# Altium schematic sheet PMTControl.SchDoc
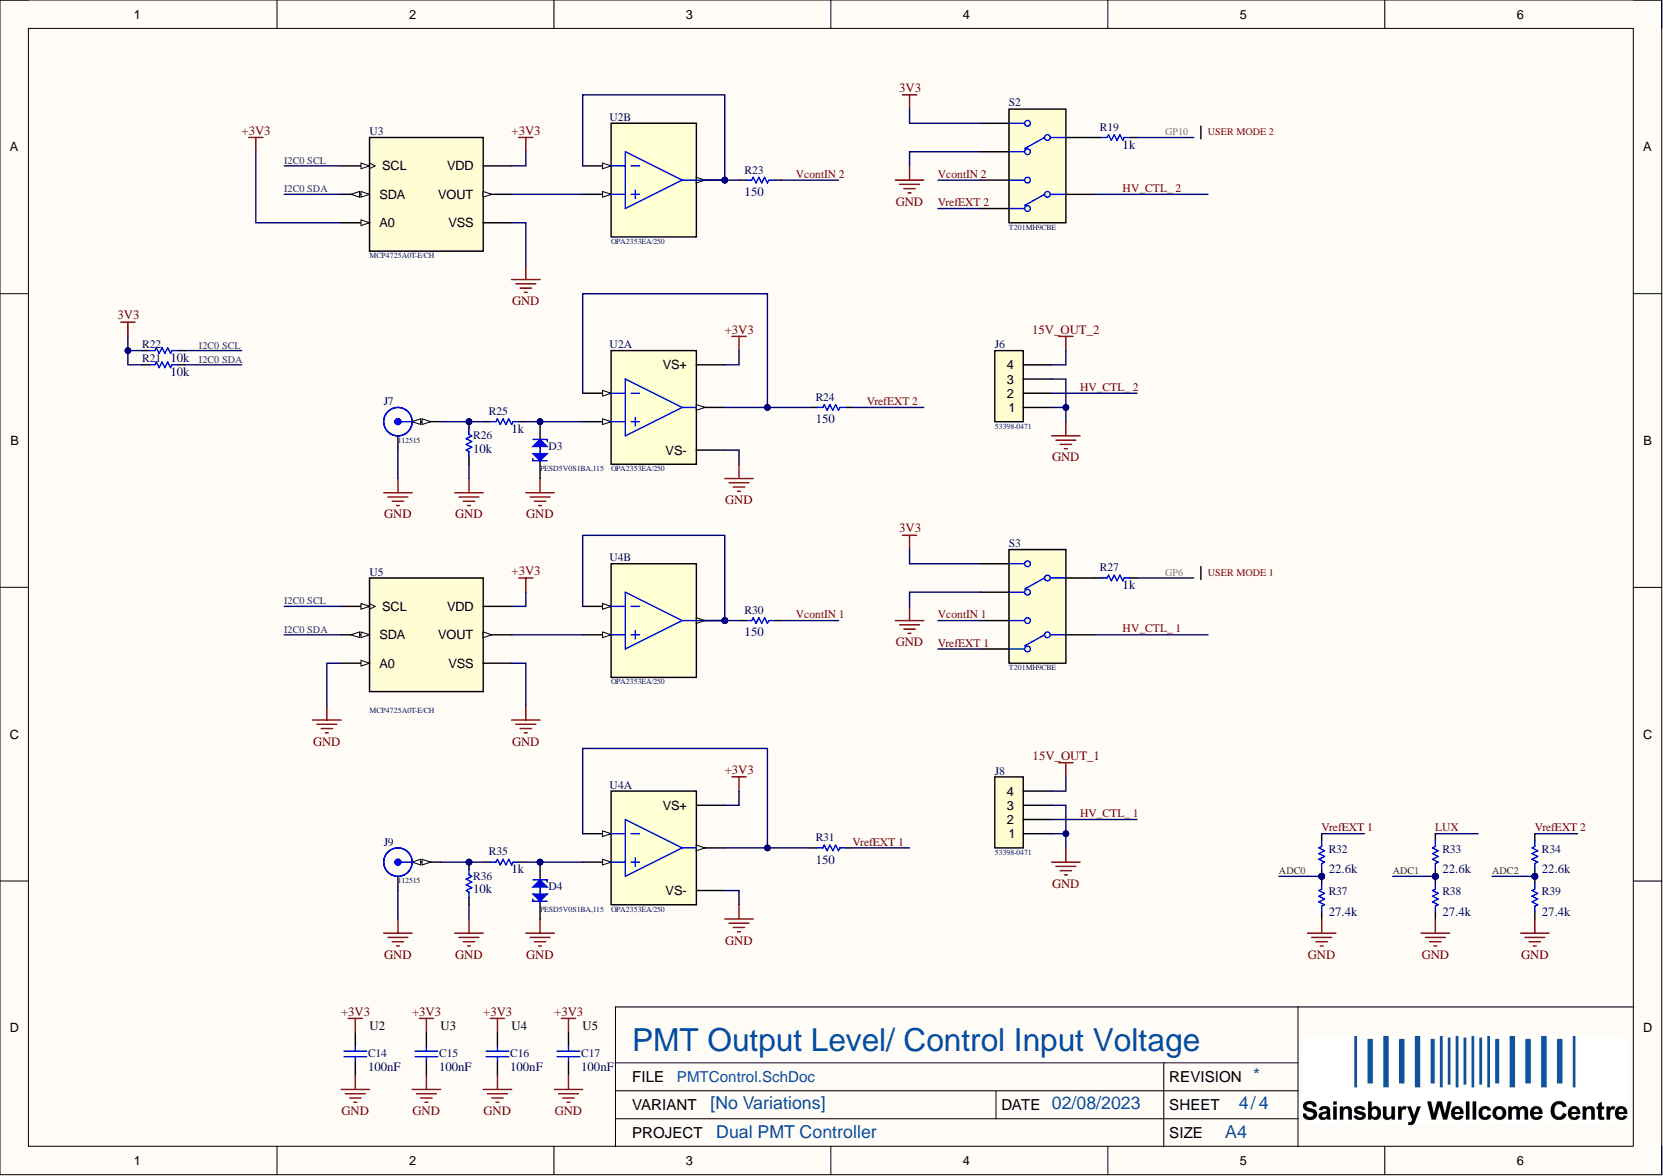
[diagram p0: PMTControl.SchDoc]

## Parts
C14  value 100nF  Capacitance: 100nF  [FP-GRM188-0_1-e0_2_0_5-IPC_B]  (hidden comment: C0603-100nF-100V-10%-X7R)
C15  value 100nF  Capacitance: 100nF  [FP-GRM188-0_1-e0_2_0_5-IPC_B]  (hidden comment: C0603-100nF-100V-10%-X7R)
C16  value 100nF  Capacitance: 100nF  [FP-GRM188-0_1-e0_2_0_5-IPC_B]  (hidden comment: C0603-100nF-100V-10%-X7R)
C17  value 100nF  Capacitance: 100nF  [FP-GRM188-0_1-e0_2_0_5-IPC_B]  (hidden comment: C0603-100nF-100V-10%-X7R)
D3  PESD5V0S1BA,115  [FP-SOD323-CA-IPC_B]
D4  PESD5V0S1BA,115  [FP-SOD323-CA-IPC_B]
J6  53398-0471  [FP-533980471-MFG]
J7  112515  [AMP_112515]
J8  53398-0471  [FP-533980471-MFG]
J9  112515  [AMP_112515]
R19  value 1k  Resistance: 1k  [FP-CPF0603-IPC_B]  (hidden comment: R0603-1k-1/16W-0.1%)
R21  value 10k  Resistance: 10k  [FP-CPF0603-IPC_B]  (hidden comment: R0603-10k-1/16W-0.1%)
R22  value 10k  Resistance: 10k  [FP-CPF0603-IPC_B]  (hidden comment: R0603-10k-1/16W-0.1%)
R23  value 150  [FP-CPF0603-IPC_B]  (hidden comment: R0603-150R-1/16W-0.1%)
R24  value 150  [FP-CPF0603-IPC_B]  (hidden comment: R0603-150R-1/16W-0.1%)
R25  value 1k  Resistance: 1k  [FP-CPF0603-IPC_B]  (hidden comment: R0603-1k-1/16W-0.1%)
R26  value 10k  Resistance: 10k  [FP-CPF0603-IPC_B]  (hidden comment: R0603-10k-1/16W-0.1%)
R27  value 1k  Resistance: 1k  [FP-CPF0603-IPC_B]  (hidden comment: R0603-1k-1/16W-0.1%)
R30  value 150  [FP-CPF0603-IPC_B]  (hidden comment: R0603-150R-1/16W-0.1%)
R31  value 150  [FP-CPF0603-IPC_B]  (hidden comment: R0603-150R-1/16W-0.1%)
R32  value 22.6k  Resistance: 22.6k  [FP-CPF0603-IPC_B]  (hidden comment: R0603-22k6-1/16W-0.1%)
R33  value 22.6k  Resistance: 22.6k  [FP-CPF0603-IPC_B]  (hidden comment: R0603-22k6-1/16W-0.1%)
R34  value 22.6k  Resistance: 22.6k  [FP-CPF0603-IPC_B]  (hidden comment: R0603-22k6-1/16W-0.1%)
R35  value 1k  Resistance: 1k  [FP-CPF0603-IPC_B]  (hidden comment: R0603-1k-1/16W-0.1%)
R36  value 10k  Resistance: 10k  [FP-CPF0603-IPC_B]  (hidden comment: R0603-10k-1/16W-0.1%)
R37  value 27.4k  Resistance: 27.4k  [FP-CPF0603-IPC_B]  (hidden comment: R0603-27k4-1/16W-0.1%)
R38  value 27.4k  Resistance: 27.4k  [FP-CPF0603-IPC_B]  (hidden comment: R0603-27k4-1/16W-0.1%)
R39  value 27.4k  Resistance: 27.4k  [FP-CPF0603-IPC_B]  (hidden comment: R0603-27k4-1/16W-0.1%)
S2  T201MH9CBE  [SWITCH_T201MH9CBE]
S3  T201MH9CBE  [SWITCH_T201MH9CBE]
U2  OPA2353EA/250  [DGK0008A_N]  (parts A,B)
U3  MCP4725A0T-E/CH  [FP-C04-028-CHY-MFG]
U4  OPA2353EA/250  [DGK0008A_N]  (parts A,B)
U5  MCP4725A0T-E/CH  [FP-C04-028-CHY-MFG]

## Nets
3V3: C14.2 C15.2 C16.2 C17.2 R21.2 R22.2 S2.1 S3.1 U2.8(VS+) U3.3(VDD) U3.6(A0) U4.8(VS+) U5.3(VDD)
15V_OUT_1: J8.4
15V_OUT_2: J6.4
GND: C14.1 C15.1 C16.1 C17.1 D3.1 D4.1 J6.1 J6.3 J7.2 J8.1 J8.3 J9.2 R26.1 R36.1 R37.1 R38.1 R39.1 S2.3 S3.3 U2.4(VS-) U3.2(VSS) U4.4(VS-) U5.2(VSS) U5.6(A0)
ADC0: R32.1 R37.2
ADC1: R33.1 R38.2
ADC2: R34.1 R39.2
GP6: R27.2
GP10: R19.2
HV_CTL_ 1: J8.2 S3.5
HV_CTL_ 2: J6.2 S2.5
I2C0 SCL: R22.1 U3.5(SCL) U5.5(SCL)
I2C0 SDA: R21.1 U3.4(SDA) U5.4(SDA)
LUX: R33.2
VcontIN 1: R30.2 S3.4
VcontIN 2: R23.2 S2.4
VrefEXT 1: R31.2 R32.2 S3.6
VrefEXT 2: R24.2 R34.2 S2.6
NetD3_2: D3.2 R25.2 U2.3
NetD4_2: D4.2 R35.2 U4.3
NetJ7_1: J7.1 R25.1 R26.2
NetJ9_1: J9.1 R35.1 R36.2
NetR19_1: R19.1 S2.2
NetR23_1: R23.1 U2.6 U2.7
NetR24_1: R24.1 U2.1 U2.2
NetR27_1: R27.1 S3.2
NetR30_1: R30.1 U4.6 U4.7
NetR31_1: R31.1 U4.1 U4.2
NetU2_5: U2.5 U3.1(VOUT)
NetU4_5: U4.5 U5.1(VOUT)

## Library data of the parts
C14-C17 = CMP-611-0027-19: 0.1µF ±10% 100V Ceramic Capacitor X7R 0603 (1608 Metric); Pick&PlaceFootprint: 0603_CAP; Capacitor Type: Ceramic; Case Code (Imperial): 0603; Case Code (Metric): 1608; Case/Package: 0603; Component Kind: Standard; Construction: Flat; Depth: 0.8mm; Dielectric: X7R; Height: 0.8mm; Lead Free: Lead Free; Length: 1.6mm; Material: Ceramic; Max Operating Temperature: 125°C; Min Operating Temperature: -55°C; Mount: Surface Mount; Package Quantity: 1; Packaging: Tape and Reel; Radiation Hardening: No; REACH SVHC: No SVHC; RoHS: Yes; RoHS Compliant: Yes; Series: GRM; Size: 0.8mm; Termination: SMD/SMT; Thickness: 0.035inch; Tolerance: 10%; Voltage: 100V; Voltage Rating: 100V; Voltage Rating (DC): 100V; Weight: 0.000222oz; Width: 0.8mm; Library Name: Sainsbury Wellcome Centre
D3 D4 = CMP-820-0001-1: Trans Voltage Suppressor Diode, 130W, 5V V(RWM), Bidirectional, 1 Element, Silicon; Max Breakdown Voltage: 9.5V; Min Breakdown Voltage: 5.5V; Number of Elements: 1; Package Body Material: Plastic; Pick&PlaceFootprint: SOD323; Reference Standard: IEC-60134; Time @ Peak Reflow Temperature-Max (s): 30s; Component Kind: Standard; Diode Element Material: SILICON; Diode Type: TRANS VOLTAGE SUPPRESSOR; JESD-30 Code: R-PDSO-G2; JESD-609 Code: e3; Max Operating Temperature: 150°C; Moisture Sensitivity Level: 1; Mount: Surface Mount; Non-rep Peak Rev Power Dis-Max: 130W; Number of Pins: 2; Package Shape: Rectangular; Package Style: SMALL OUTLINEMeter; PartNumber: PESD5V0S1BA,115; Peak Reflow Temperature (Cel): 260°C; Polarity: Bidirectional; REACH SVHC: Yes; Rep Pk Reverse Voltage-Max: 5V; RoHS Compliant: Yes; Surface Mount: Yes; Technology: AVALANCHE; Terminal Finish: Tin; Terminal Form: Gull Wing; Terminal Position: DUAL; Library Name: Sainsbury Wellcome Centre
J6 J8 = CMP-370-0030-2: Board Connector, 4 Contact(s), 1 Row(s), Male, Straight, 0.049 inch Pitch, Surface Mount Terminal, Locking, Natural Insulator, Receptacle; Board Mounting Option: FITTING NAIL; Body Breadth: 0.146inch; Body Depth: 0.185inch; Body Length: 0.383inch; Contact Pattern: RECTANGULAR; Contact Resistance: 20mR; Contact Style: Blade Pin; Dielectric Withstanding Voltage (AC): 250V; DIN Conformance: No; Durability: 30Cycles; Insulation Resistance: 100MR; Mating Information: MULTIPLE MATING PARTS AVAILABLE; Number Of Connectors: 1; Number of Contacts: 4; Option: General Purpose; Plating Thickness: 39uin; Polarization Key: POLARIZED HOUSING; Rated Current (Signal): 1A; Reference Standard: UL; Reliability: COMMERCIAL; Body/Shell Style: RECEPTACLE; Component Kind: Standard; Connector Type: BOARD CONNECTOR; Contact Finish - Mating: MATTE TIN (39) OVER NICKEL; Contact Finish - Termination: Matte Tin (Sn) - with Nickel (Ni) barrier; Contact Gender: Male; Contact Material: Bronze; Filter Feature: No; Flammability Rating: UL94 V-0; IEC Conformance: No; Insulation Color: Natural; Insulation Material: Polyamide; JESD-609 Code: e3; Mating Contact Pitch: 0.049inch; Max Operating Temperature: 85°C; MIL Conformance: No; Min Operating Temperature: -40°C; Mixed Contacts: No; Mount: Board; Mounting Option 1: LOCKING; Mounting Style: STRAIGHT; Number Of PCB Rows: 1; Number of Rows Loaded: 1; PartNumber: 53398-0471; PCB Contact Pattern: RECTANGULAR; REACH SVHC: Yes; RoHS Compliant: Yes; Terminal Pitch: 1.25mm; Termination: SMD/SMT; Library Name: Sainsbury Wellcome Centre
J7 J9 = CMP-730-0003-6: RF BNC Connector, Female, Board Mount, Solder Terminal, Jack; Characteristic Impedance: 50R; Coupling Type: Bayonet; DIN Conformance: No; Option: General Purpose; Panel Mount: No; Body/Shell Style: JACK; Component Kind: Standard; Connector Type: BNC; Contact Finish - Mating: NOT SPECIFIED; Contact Gender: Female; Filter Feature: No; IEC Conformance: No; Insulation Material: POLYTETRAFLUORO; MIL Conformance: No; Mount: Board; Mounting Style: STRAIGHT; PartNumber: 112515; REACH SVHC: No SVHC; RoHS Compliant: Yes; Termination: Solder; Library Name: Sainsbury Wellcome Centre
R19 R25 R27 R35 = CMP-633-0013-15: Surface Mount Resistor 1k Ohm 1/16 W 0.1% 0603 (1608 Metric); Max Power Dissipation: 62.5 mW; Number of Resistors: 1; Pick&PlaceFootprint: R0603; Power Rating: 62.5mW; Temperature Coefficient: 25ppm/°C; Voltage Rating: 50V; Case Code (Imperial): 0603; Case Code (Metric): 1608; Case/Package: 0603; Component Kind: Standard; Composition: Thin Film; ELV: Compliant; Height: 0.45mm; Length: 1.6mm; Max Operating Temperature: 155°C; Min Operating Temperature: -55°C; Mount: Surface Mount; Number of Pins: 2; Number of Terminations: 2; Packaging: Tape and Reel; REACH SVHC: No SVHC; Resistance Tolerance: 0.1%; Resistor Type: Thin Film; RoHS: Yes; RoHS Compliant: Yes; Series: CPF; Size Code: 0603; Technology: Thin Film; Termination: Solder; Tolerance: 0.1%; Voltage Rating (DC): 50V; Weight: 7.1E-05oz; Width: 0.8mm; Library Name: Sainsbury Wellcome Centre
R21 R22 R26 R36 = CMP-633-0002-15: Surface Mount Resistor 10k Ohm 1/16 W 0.1% 0603 (1608 Metric); Max Power Dissipation: 62.5 mW; Number of Resistors: 1; Pick&PlaceFootprint: R0603; Power Rating: 62.5mW; Temperature Coefficient: 25ppm/°C; Voltage Rating: 50V; Case Code (Imperial): 0603; Case Code (Metric): 1608; Case/Package: 0603; Component Kind: Standard; Composition: Thin Film; ELV: Compliant; Height: 0.45mm; Length: 1.6mm; Max Operating Temperature: 155°C; Min Operating Temperature: -55°C; Mount: Surface Mount; Number of Pins: 2; Number of Terminations: 2; Packaging: Tape and Reel; REACH SVHC: No SVHC; Resistance Tolerance: 0.1%; Resistor Type: Thin Film; RoHS: Yes; RoHS Compliant: Yes; Series: CPF; Size Code: 0603; Technology: Thin Film; Termination: Solder; Tolerance: 0.1%; Voltage Rating (DC): 50V; Weight: 7.1E-05oz; Width: 0.8mm; Library Name: Sainsbury Wellcome Centre
R23 R24 R30 R31 = CMP-633-0078-2: Surface Mount Resistor 150 Ohm 1/16 W 0.1% 0603 (1608 Metric); Max Power Dissipation: 62.5 mW; Number of Resistors: 1; Pick&PlaceFootprint: R0603; Power Rating: 62.5mW; Temperature Coefficient: 25ppm/°C; Voltage Rating: 50V; Case Code (Imperial): 0603; Case Code (Metric): 1608; Case/Package: 0603; Component Kind: Standard; Composition: Thin Film; Construction: Chip; ELV: Compliant; Height: 0.45mm; Length: 1.6mm; Max Operating Temperature: 155°C; Min Operating Temperature: -55°C; Mount: Surface Mount; Number of Pins: 2; Number of Terminations: 2; Packaging: Tape and Reel; REACH SVHC: Yes; Resistance: 150; Resistance Tolerance: 0.1%; Resistor Type: FIXED RESISTOR; RoHS: Yes; RoHS Compliant: Yes; Series: CPF; Size Code: 0603; Technology: THIN FILM; Termination: Wraparound; Tolerance: 0.1%; Voltage Rating (DC): 50V; Weight: 7.1E-05oz; Width: 0.8mm; Library Name: Sainsbury Wellcome Centre
R32-R34 = CMP-633-0059-13: Surface Mount Resistor 22.6k Ohm 1/16 W 0.1% 0603 (1608 Metric); Max Power Dissipation: 62.5 mW; Number of Resistors: 1; Pick&PlaceFootprint: R0603; Power Rating: 62.5mW; Temperature Coefficient: 25ppm/°C; Voltage Rating: 50V; Case Code (Imperial): 0603; Case Code (Metric): 1608; Case/Package: 0603; Component Kind: Standard; Composition: Thin Film; ELV: Compliant; Height: 0.45mm; Length: 1.6mm; Max Operating Temperature: 155°C; Min Operating Temperature: -55°C; Mount: Surface Mount; Number of Pins: 2; Number of Terminations: 2; Packaging: Tape and Reel; REACH SVHC: No SVHC; Resistance Tolerance: 0.1%; Resistor Type: Thin Film; RoHS: Yes; RoHS Compliant: Yes; Series: CPF; Size Code: 0603; Technology: Thin Film; Termination: Solder; Tolerance: 0.1%; Voltage Rating (DC): 50V; Weight: 7.1E-05oz; Width: 0.8mm; Library Name: Sainsbury Wellcome Centre
R37-R39 = CMP-633-0060-13: Surface Mount Resistor 27.4k Ohm 1/16 W 0.1% 0603 (1608 Metric); Max Power Dissipation: 62.5 mW; Number of Resistors: 1; Pick&PlaceFootprint: R0603; Power Rating: 62.5mW; Temperature Coefficient: 25ppm/°C; Voltage Rating: 50V; Case Code (Imperial): 0603; Case Code (Metric): 1608; Case/Package: 0603; Component Kind: Standard; Composition: Thin Film; ELV: Compliant; Height: 0.45mm; Length: 1.6mm; Max Operating Temperature: 155°C; Min Operating Temperature: -55°C; Mount: Surface Mount; Number of Pins: 2; Number of Terminations: 2; Packaging: Tape and Reel; REACH SVHC: No SVHC; Resistance Tolerance: 0.1%; Resistor Type: Thin Film; RoHS: Yes; RoHS Compliant: Yes; Series: CPF; Size Code: 0603; Technology: Thin Film; Termination: Solder; Tolerance: 0.1%; Voltage Rating (DC): 50V; Weight: 7.1E-05oz; Width: 0.8mm; Library Name: Sainsbury Wellcome Centre
S2 S3 = CMP-B00-0010-1: Toggle Switch, DPDT, On-on, Horizontal, 6 PCB Hole Cnt, Solder Terminal, Toggle Bat Actuator, Through Hole-straight; Case/Package: MH9CBE; Component Kind: Standard; Mount: Through Hole; PartNumber: T201MH9CBE; Library Name: Sainsbury Wellcome Centre
U2 U4 = CMP-941-0006-5: Operational Amplifier, 2 Func, 8000uV Offset-Max, CMOS, PDSO8; Package Equivalence Code: TSSOP8,.19; Pick&PlaceFootprint: TSSOP8; Component Kind: Standard; PartNumber: OPA2353EA/250; Library Name: Sainsbury Wellcome Centre
U3 U5 = CMP-9B0-0000-1: DAC 1-CH Resistor-String 12-bit 6-Pin SOT-23A T/R; Input Offset Voltage (Vos): 1.1mV; Input Type: SERIAL; Integral Nonlinearity (INL): 14.5LSB; Interface: I2C,Serial,2-Wire; Max Supply Voltage: 5.5V; Min Supply Voltage: 2.7V; Nominal Supply Current: 210uA; Number of Bits: 12; Number of Channels: 1; Output Polarity: Unipolar; Output Type: Voltage; Resolution: 12b; Settling Time: 6us; Supply Type: Single; Case/Package: SOT-23-6; Component Kind: Standard; Contact Plating: Tin,Matte; Height: 1.3mm; Lead Free: Lead Free; Length: 3.1mm; Max Operating Temperature: 125°C; Min Operating Temperature: -40°C; Mount: Surface Mount; Number of Converters: 1; Number of D/A Converters: 1; Number of DAC Channels: 1; Number of Outputs: 1; Number of Pins: 6; Package Quantity: 3000; Packaging: Tape and Reel; Polarity: Unipolar; Radiation Hardening: No; REACH SVHC: No SVHC; Supply Current: 210uA; Termination: SMD/SMT; Width: 1.8mm; Library Name: Sainsbury Wellcome Centre
